# Altium schematic sheet mcu.SchDoc
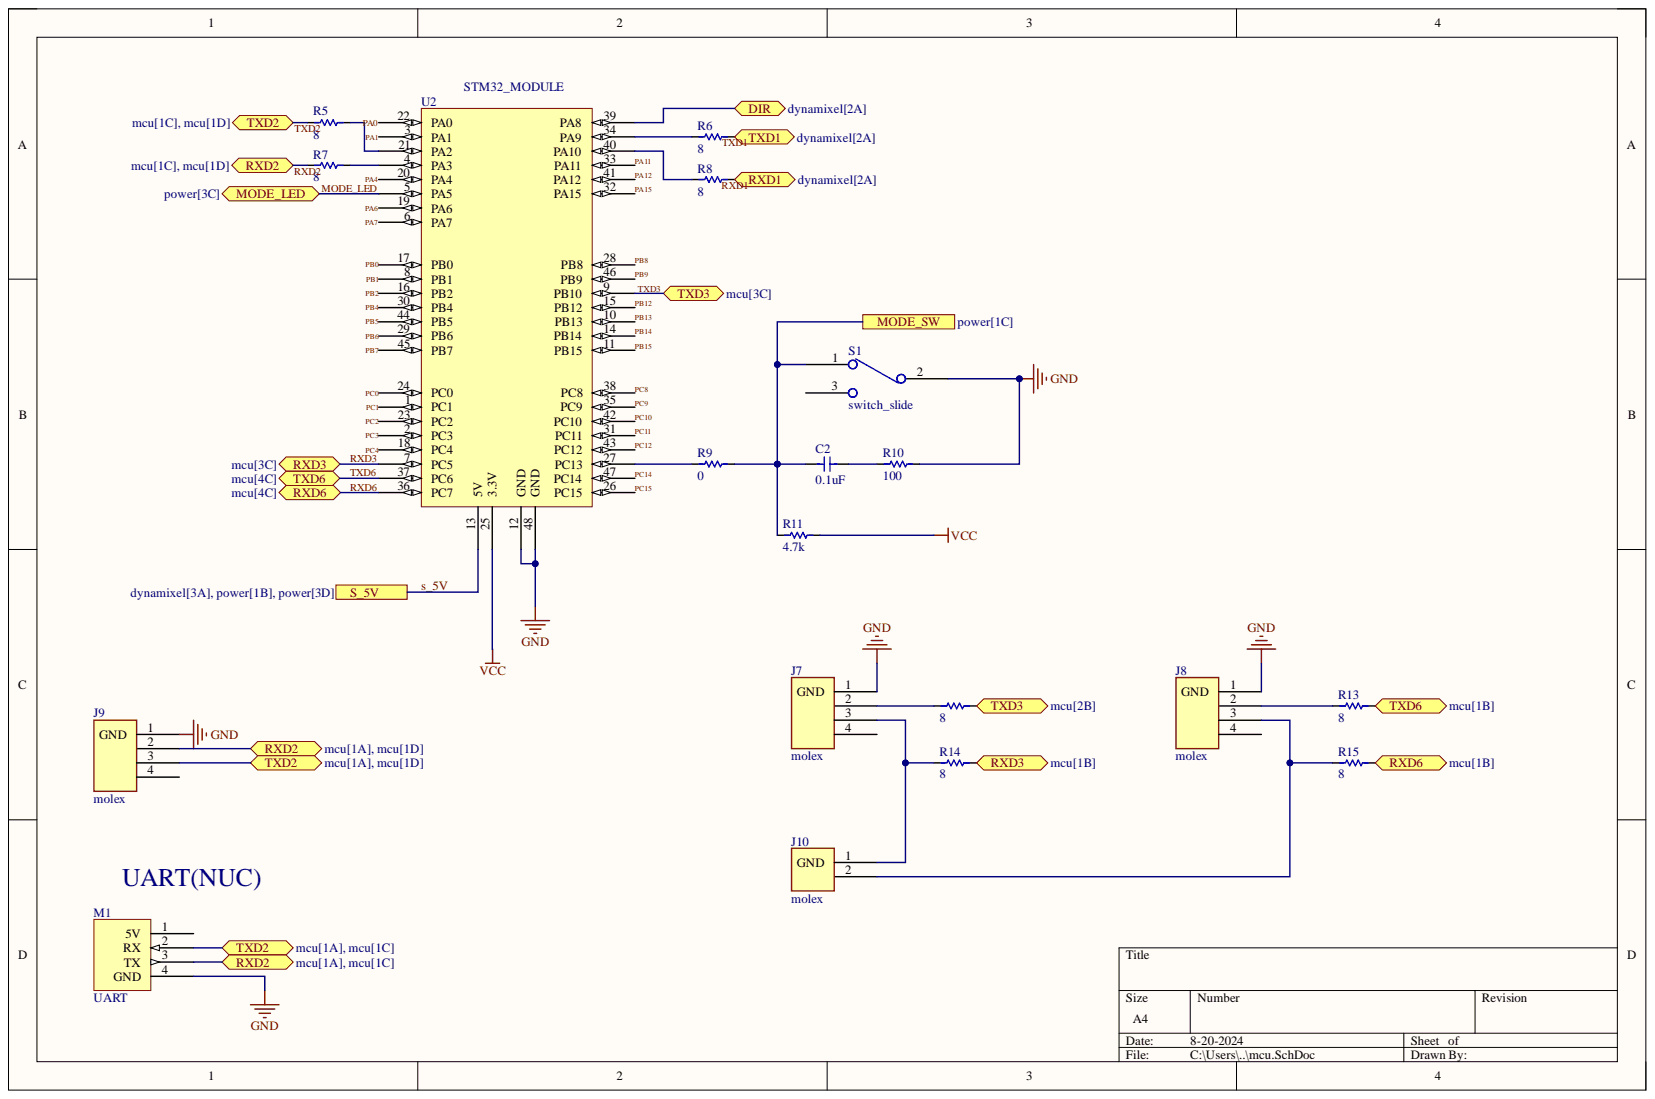
[diagram p0: mcu.SchDoc]

## Parts
C2  Capacitance: 0.1uF  [SMD_Capacitor]  (hidden comment: non-polar_Capacitor)
J7  molex  [MOLEX_4PIN]
J8  molex  [MOLEX_4PIN]
J9  molex  [MOLEX_4PIN]
J10  molex  [MOLEX_2PIN]
M1  UART  [USB2UART]
R5  Resistor  [SMD_Resistor]  (value not drawn)
R6  Resistor  [SMD_Resistor]  (value not drawn)
R7  Resistor  [SMD_Resistor]  (value not drawn)
R8  Resistor  [SMD_Resistor]  (value not drawn)
R9  Resistor  [SMD_Resistor]  (value not drawn)
R10  Resistor  [SMD_Resistor]  (value not drawn)
R11  Resistance: 4.7k  [SMD_Resistor]  (hidden comment: Resistor)
R13  Resistor  [SMD_Resistor]  (value not drawn)
R14  Resistor  [SMD_Resistor]  (value not drawn)
R15  Resistor  [SMD_Resistor]  (value not drawn)
S1  switch_slide  [DIP_SW_SLIDE]
U2  STM32_MODULE  [DIP_STM32_MODULE]
  Resistor  [SMD_Resistor]  (value not drawn)

## Ports
DIR, MODE_LED, MODE_SW, RXD1, RXD2, RXD3, RXD6, S_5V, TXD1, TXD2, TXD3, TXD6

## Nets
GND: J7.1(GND) J8.1(GND) J9.1(GND) M1.4(GND) R10.2 S1.2 U2.12(GND) U2.48(GND)
Net_1: J7.2 .1
VCC: R11.2 U2.25(3.3V)
DIR: U2.39(PA8) ; port DIR
MODE_LED: U2.5(PA5) ; port MODE_LED
MODE_SW: C2.1 R9.2 R11.1 S1.1 ; port MODE_SW
PA0: U2.22(PA0)
PA1: U2.3(PA1)
PA4: U2.20(PA4)
PA6: U2.19(PA6)
PA7: U2.6(PA7)
PA11: U2.33(PA11)
PA12: U2.41(PA12)
PA15: U2.32(PA15)
PB0: U2.17(PB0)
PB1: U2.8(PB1)
PB2: U2.16(PB2)
PB4: U2.30(PB4)
PB5: U2.44(PB5)
PB6: U2.29(PB6)
PB7: U2.45(PB7)
PB8: U2.28(PB8)
PB9: U2.46(PB9)
PB12: U2.15(PB12)
PB13: U2.10(PB13)
PB14: U2.14(PB14)
PB15: U2.11(PB15)
PC0: U2.24(PC0)
PC1: U2.1(PC1)
PC2: U2.23(PC2)
PC3: U2.2(PC3)
PC4: U2.18(PC4)
PC8: U2.38(PC8)
PC9: U2.35(PC9)
PC10: U2.42(PC10)
PC11: U2.31(PC11)
PC12: U2.43(PC12)
PC14: U2.47(PC14)
PC15: U2.26(PC15)
RXD1: R8.2 ; port RXD1
RXD2: J9.2 M1.3(TX) R7.1 ; port RXD2
RXD3: R14.2 U2.7(PC5) ; port RXD3
RXD6: R15.2 U2.36(PC7) ; port RXD6
s_5V: U2.13(5V) ; port S_5V
TXD1: R6.2 ; port TXD1
TXD2: J9.3 M1.2(RX) R5.1 ; port TXD2
TXD3: U2.9(PB10) .2 ; port TXD3
TXD6: R13.2 U2.37(PC6) ; port TXD6
NetC2_2: C2.2 R10.1
NetJ7_3: J7.3 J10.1 R14.1
NetJ8_2: J8.2 R13.1
NetJ8_3: J8.3 J10.2 R15.1
NetR5_2: R5.2 U2.21(PA2)
NetR6_1: R6.1 U2.34(PA9)
NetR7_2: R7.2 U2.4(PA3)
NetR8_1: R8.1 U2.40(PA10)
NetR9_1: R9.1 U2.27(PC13)

Not connected: J7 4; J8 4; J9 4; M1 1(5V); S1 3

## Library data of the parts
C2 = CMP-024-000020-2: SMD type ceramic capacitor; Component Kind: Standard; Design Item ID: Capacitor; Library Name: robit circuit; Source: robit_passive.SchLib
J7-J9 = CMP-019-000045-1: Molex 4Pin Connector; Component Kind: Standard; Design Item ID: Molex_4Pin; Library Name: robit circuit; Source: robit_connector.SchLib
J10 = CMP-019-000043-1: Molex 2Pin Connector; Component Kind: Standard; Design Item ID: Molex_2Pin; Library Name: robit circuit; Source: robit_connector.SchLib
M1 = CMP-037-000002-3: Connector, uart232; Component Kind: Standard; Design Item ID: UART; Library Name: robit circuit; Source: robit_connector.SchLib
R5-R8 R13-R15  = CMP-029-000004-2: SMD type resistor; Resistance: 8; Component Kind: Standard; Design Item ID: Resistor; Library Name: robit circuit; Source: robit_passive.SchLib
R9 = CMP-029-000004-2: SMD type resistor; Resistance: 0; Component Kind: Standard; Design Item ID: Resistor; Library Name: robit circuit; Source: robit_passive.SchLib
R10 = CMP-029-000004-2: SMD type resistor; Resistance: 100; Component Kind: Standard; Design Item ID: Resistor; Library Name: robit circuit; Source: robit_passive.SchLib
R11 = CMP-029-000004-2: SMD type resistor; Component Kind: Standard; Design Item ID: Resistor; Library Name: robit circuit; Source: robit_passive.SchLib
S1 = CMP-030-000004-1: slide switch; Component Kind: Standard; Design Item ID: switch_slide; Library Name: robit circuit; Source: robit_switch.SchLib
U2 = CMP-021-000010-1: processor; Component Kind: Standard; Design Item ID: STM32_MODULE; Library Name: robit circuit; Source: robit_ic.SchLib
